# Altium schematic sheet Converter.SchDoc(Converter)
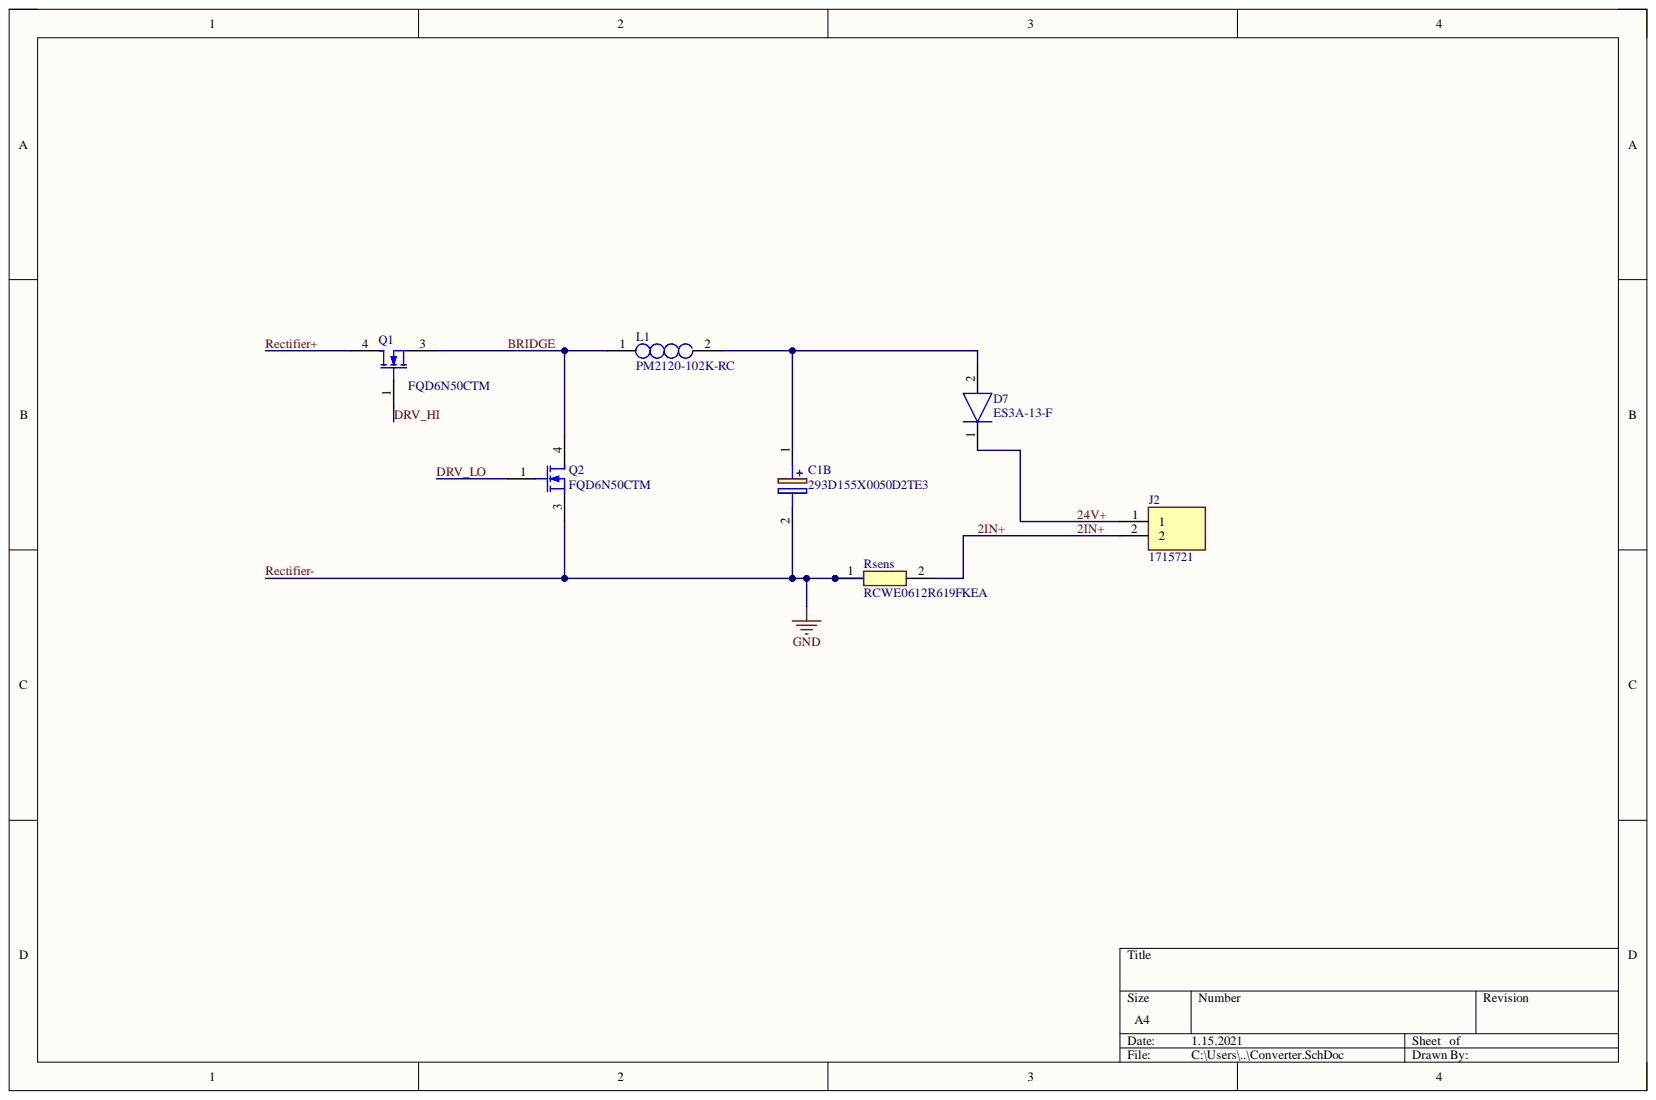
[diagram p0: Converter.SchDoc]

## Parts
C1B  293D155X0050D2TE3  [CAPPM7343X310N]
D7  ES3A-13-F  [DIOM7959X250N]
J2  1715721  [1715721]
L1  PM2120-102K-RC  [PM2120271KRC]
Q1  FQD6N50CTM  [DPAK3]
Q2  FQD6N50CTM  [DPAK3]
Rsens  RCWE0612R619FKEA  [RESC1632X70N]

## Nets
2IN+: J2.2 Rsens.2
24V+: D7.1 J2.1
BRIDGE: L1.1 Q1.3 Q2.4
DRV_HI: Q1.1
DRV_LO: Q2.1
Rectifier+: Q1.4
Rectifier-: C1B.2 Q2.3 Rsens.1
NetC1B_1: C1B.1 D7.2 L1.2

## Library data of the parts
C1B = 293D155X0050D2TE3: Capacitor Polarised; Manufacturer_Name: Vishay; Component Kind: Standard; Datasheet Link: http://www.vishay.com/doc?40002; Height: 3.1mm; Manufacturer_Part_Number: 293D155X0050D2TE3; Mouser Part Number: N/A; Mouser Price/Stock: https://www.mouser.co.uk/ProductDetail/Vishay-Sprague/293D155X0050D2TE3/?qs=dG4nGvFc7P9fHhfu7ckfoQ%3D%3D
D7 = ES3A-13-F: Diode; Manufacturer_Name: Diodes Inc.; Arrow Part Number: ES3A-13-F; Arrow Price/Stock: https://www.arrow.com/en/products/es3a-13-f/diodes-incorporated; Component Kind: Standard; Datasheet Link: https://www.arrow.com/en/products/es3a-13-f/diodes-incorporated; Height: 2.5mm; Manufacturer_Part_Number: ES3A-13-F; Mouser Part Number: 621-ES3A-F; Mouser Price/Stock: https://www.mouser.co.uk/ProductDetail/Diodes-Incorporated/ES3A-13-F/?qs=fsGQ151tk6Wkygd1WaXOlQ%3D%3D
J2 = 1715721: Connector; Manufacturer_Name: Phoenix Contact; Arrow Part Number: 1715721; Arrow Price/Stock: https://www.arrow.com/en/products/1715721/phoenix-contact; Component Kind: Standard; Datasheet Link: https://componentsearchengine.com/Datasheets/2/1715721.pdf; Height: 13.95mm; Manufacturer_Part_Number: 1715721; Mouser Part Number: 651-1715721; Mouser Price/Stock: https://www.mouser.co.uk/ProductDetail/Phoenix-Contact/1715721/?qs=BYlwlsDsB%2FqgmKyTCSucHg%3D%3D
L1 = PM2120-102K-RC: Inductor; Manufacturer_Name: Bourns; Component Kind: Standard; Datasheet Link: https://www.bourns.com/pdfs/pm2120_series.pdf; Height: 20.32mm; Manufacturer_Part_Number: PM2120-102K-RC
Q1 Q2 = FQD6N50CTM: Transistor, Mosfet, N-Channel, 500V V(Br)Dss, 4.5A I(D), To-252Aa; Manufacturer: ON Semiconductor / Fairchild; Drain to Source Resistance: 1.2R; Element Configuration: Single; Fall Time: 45ns; Gate to Source Voltage (Vgs): 30V; Max Power Dissipation: 2.5W; Number of Elements: 1; Rise Time: 35ns; Turn-Off Delay Time: 55ns; Turn-On Delay Time: 10ns; Input Capacitance: 700pF; Case/Package: DPAK; Category: MOSFETs; Component Kind: Standard; ComponentLink1Description: https://datasheet.ciiva.com/11175/fqd6n50c-11175856.pdf?src-supplier=Avnet; ComponentLink1URL: https://datasheet.ciiva.com/11175/fqd6n50c-11175856.pdf?src-supplier=Avnet; ComponentLink2Description: https://datasheet.ciiva.com/4445/fqd6n50c-110706-4445904.pdf?src-supplier=Mouser; ComponentLink2URL: https://datasheet.ciiva.com/4445/fqd6n50c-110706-4445904.pdf?src-supplier=Mouser; ComponentLink3Description: https://datasheet.ciiva.com/26791/fqd6n50ctm-26791044.pdf?src-supplier=IIIC; ComponentLink3URL: https://datasheet.ciiva.com/26791/fqd6n50ctm-26791044.pdf?src-supplier=IIIC; Continuous Drain Current (ID): 4.5A; Current Rating: 4.5A; Drain to Source Breakdown Voltage: 500V; Drain to Source Voltage (Vdss): 500V; Lead Free: Lead Free; Library Name: Schlib1.SchLib; Manufacturer Part Number: FQD6N50CTM; Max Operating Temperature: 150°C; Min Operating Temperature: -55°C; Mount: Surface Mount; Number of Pins: 3; Package Quantity: 1; Packaging: Tape and Reel; Power Dissipation: 2.5W; Pricing 1: 1=0,95000, 10=0,77600, 25=0,76200, 100=0,60380, 500=0,51176, 1000=0,41688 (USD); Radiation Hardening: No; Rds On Max: 1.2R; RoHS: Yes; Stock 1: 650; Supplier 1: Digi-Key; Supplier Part Number 1: FQD6N50CTMCT-ND; Voltage Rating (DC): 500V; Weight: 0.26037g
Rsens = RCWE0612R619FKEA: Resistor; Manufacturer_Name: Vishay; Arrow Part Number: RCWE0612R619FKEA; Arrow Price/Stock: https://www.arrow.com/en/products/rcwe0612r619fkea/vishay; Component Kind: Standard; Datasheet Link: https://componentsearchengine.com/Datasheets/2/RCWE0612R619FKEA.pdf; Height: 0.7mm; Manufacturer_Part_Number: RCWE0612R619FKEA; Mouser Part Number: 71-RCWE0612R619FKEA; Mouser Price/Stock: https://www.mouser.co.uk/ProductDetail/Vishay-Dale/RCWE0612R619FKEA/?qs=bvCpHSZmQjl4FfGNZ007qQ%3D%3D
